# Altium schematic sheet nbiot_st.SchDoc(nbiot_st)
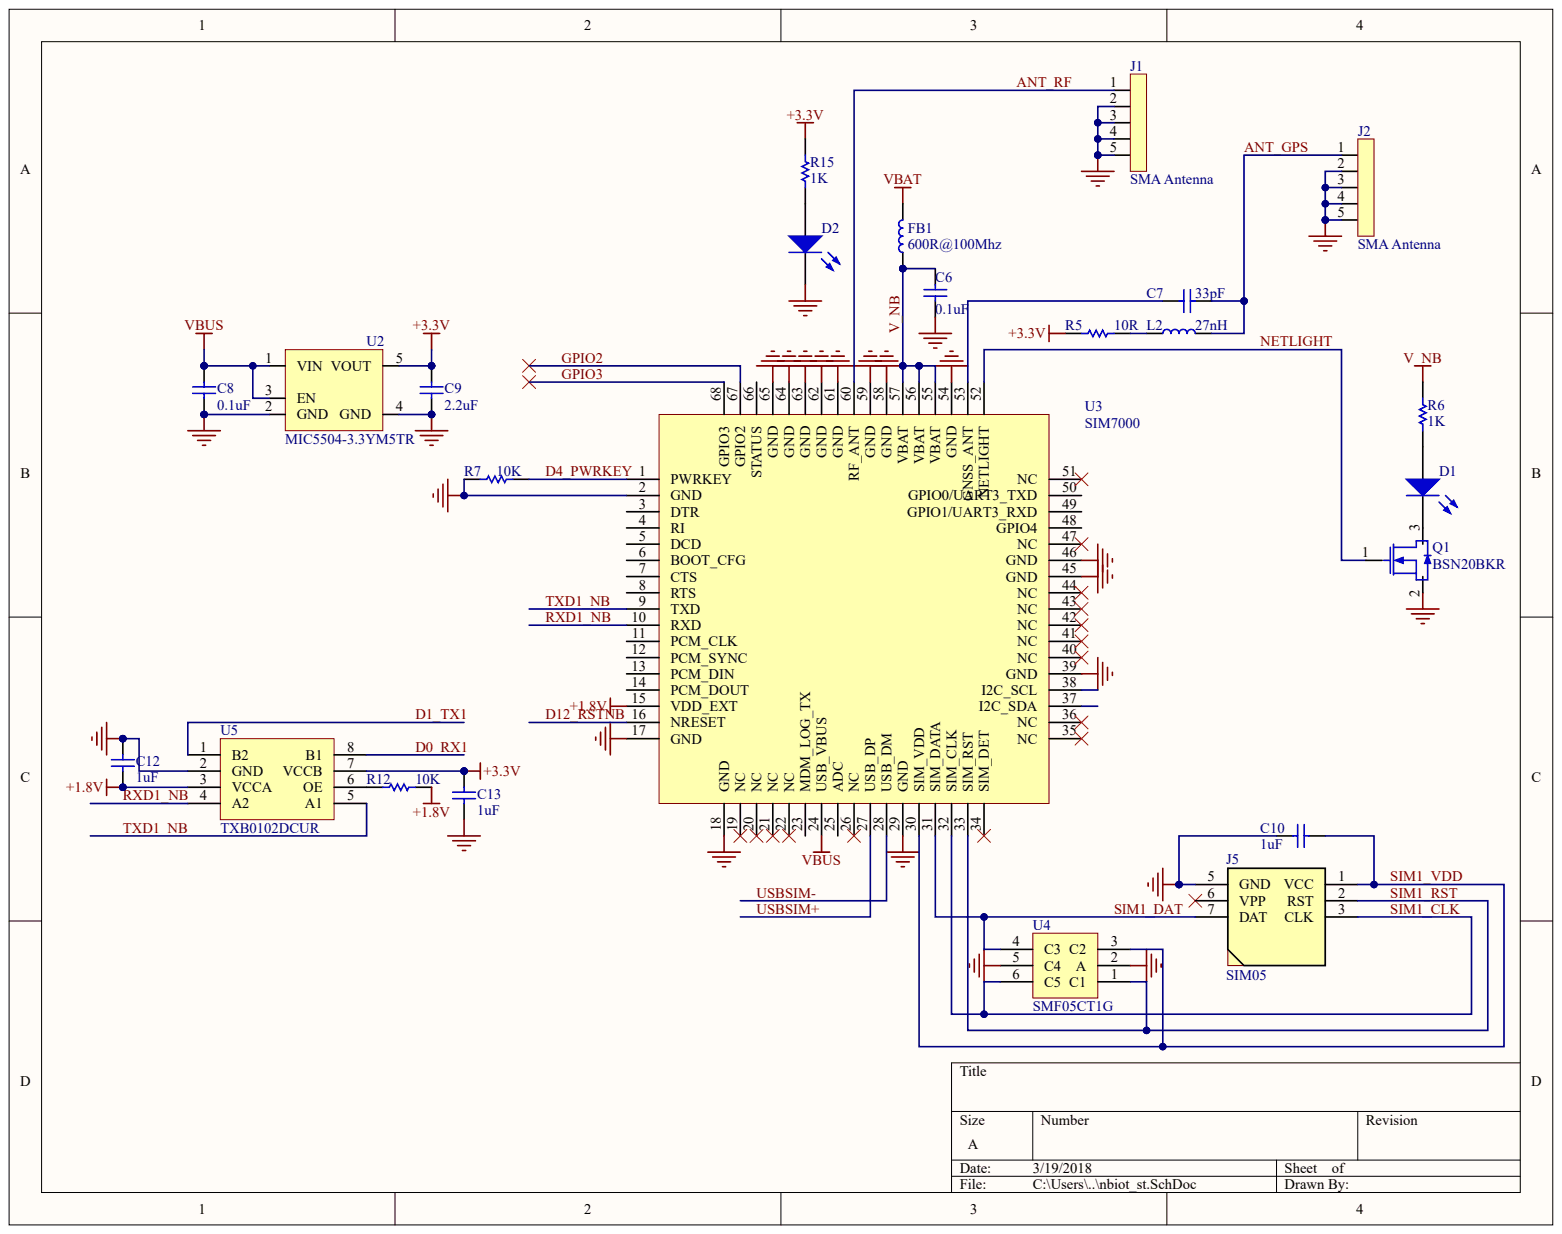
[diagram p0: nbiot_st.SchDoc]

## Parts
C6  0.1uF
C7  33pF
C8  0.1uF
C9  2.2uF
C10  1uF
C12  1uF
C13  1uF
D1  LG Q971-KN-1  (value not drawn)
D2  LG Q971-KN-1  (value not drawn)
FB1  600R@100Mhz
J1  SMA Antenna
J2  SMA Antenna
J5  SIM05
L2  27nH
Q1  BSN20BKR
R5  10R
R6  1K
R7  10K
R12  10K
R15  1K
U2  MIC5504-3.3YM5TR
U3  SIM7000
U4  SMF05CT1G
U5  TXB0102DCUR

## Nets
+1.8V: C12.2 R12.2 U3.15(VDD_EXT) U5.3(VCCA)
+3.3V: C9.2 C13.2 R5.1 R15.1 U2.5(VOUT) U5.7(VCCB)
GND: C6.2 C8.1 C9.1 C10.1 C12.1 C13.1 D2.2 J1.2 J1.3 J1.4 J1.5 J2.2 J2.3 J2.4 J2.5 J5.5(GND) Q1.2 R7.2 U2.2(GND) U2.4(GND) U3.2(GND) U3.17(GND) U3.18(GND) U3.29(GND) U3.39(GND) U3.45(GND) U3.46(GND) U3.54(GND) U3.58(GND) U3.59(GND) U3.61(GND) U3.62(GND) U3.63(GND) U3.64(GND) U3.65(GND) U4.2(A) U4.5(C4) U5.2(GND)
VBAT: FB1.2
VBUS: C8.2 U2.1(VIN) U2.3(EN) U3.24(USB_VBUS)
ANT_GPS: C7.2 J2.1 L2.2
ANT_RF: J1.1 U3.60(RF_ANT)
D0_RX1: U5.8(B1)
D1_TX1: U5.1(B2)
D4_PWRKEY: R7.1 U3.1(PWRKEY)
D12_RSTNB: U3.16(NRESET)
GPIO2: U3.67(GPIO2)
GPIO3: U3.68(GPIO3)
NETLIGHT: Q1.1 U3.52(NETLIGHT)
RXD1_NB: U3.10(RXD) U5.4(A2)
SIM1_CLK: J5.3(CLK) U3.32(SIM_CLK) U4.6(C5)
SIM1_DAT: J5.7(DAT) U3.31(SIM_DATA) U4.4(C3)
SIM1_RST: J5.2(RST) U3.33(SIM_RST) U4.1(C1)
SIM1_VDD: C10.2 J5.1(VCC) U3.30(SIM_VDD) U4.3(C2)
TXD1_NB: U3.9(TXD) U5.5(A1)
USBSIM+: U3.27(USB_DP)
USBSIM-: U3.28(USB_DM)
V_NB: C6.1 FB1.1 R6.1 U3.55(VBAT) U3.56(VBAT) U3.57(VBAT)
NetC7_1: C7.1 U3.53(GNSS_ANT)
NetD1_1: D1.1 R6.2
NetD1_2: D1.2 Q1.3
NetD2_1: D2.1 R15.2
NetL2_1: L2.1 R5.2
NetR12_1: R12.1 U5.6(OE)

Not connected: J5 6(VPP); U3 3(DTR) 4(RI) 5(DCD) 6(BOOT_CFG) 7(CTS) 8(RTS) 11(PCM_CLK) 12(PCM_SYNC) 13(PCM_DIN) 14(PCM_DOUT) 19(NC) 20(NC) 21(NC) 22(NC) 23(MDM_LOG_TX) 25(ADC) 26(NC) 34(SIM_DET) 35(NC) 36(NC) 37(I2C_SDA) 38(I2C_SCL) 40(NC) 41(NC) 42(NC) 43(NC) 44(NC) 47(NC) 48(GPIO4) 49(GPIO1/UART3_RXD) 50(GPIO0/UART3_TXD) 51(NC) 66(STATUS)

## Library data of the parts
C6 = (no symbol name): Tolerance: 10%; Voltage Rating: 50V; BOM: (NI); Value: 0.1uF
C7-C10 C12 C13 = (no symbol name): Tolerance: 10%; Voltage Rating: 50V
  C7: Value: 33pF
  C8: Value: 0.1uF
  C9: Value: 2.2uF
  C10 C12 C13: Value: 1uF
D1 D2 = (no symbol name): Manufacturer: OSRAM; PartNumber: LG Q971-KN-1
FB1 L2 R5-R7 R12 R15 = (no symbol name): Tolerance: 1%
  FB1: Value: 600R@100Mhz
  L2: Value: 27nH
  R5: Value: 10R
  R6 R15: Value: 1K
  R7 R12: Value: 10K
J1 J2 = (no symbol name): PartNumber: 5-1814832-1; Manufacturer: TE
J5 = (no symbol name): Manufacturer: ADC; PartNumber: SIM05
Q1 = (no symbol name): Manufacturer: Nexperia; PartNumber: BSN20BKR
U2 = (no symbol name): Manufacturer: Microchip; PartNumber: MIC5504-3.3YM5TR
U3 = (no symbol name): Manufacturer: SIMCOM; PartNumber: SIM7000
U4 = (no symbol name): Manufacturer: On Semi; PartNumber: SMF05CT1G
U5 = (no symbol name): Manufacturer: TI; PartNumber: TXB0102DCUR
